# Altium schematic sheet pw_contr_v1_s1.SchDoc(pw_contr_v1_s1)
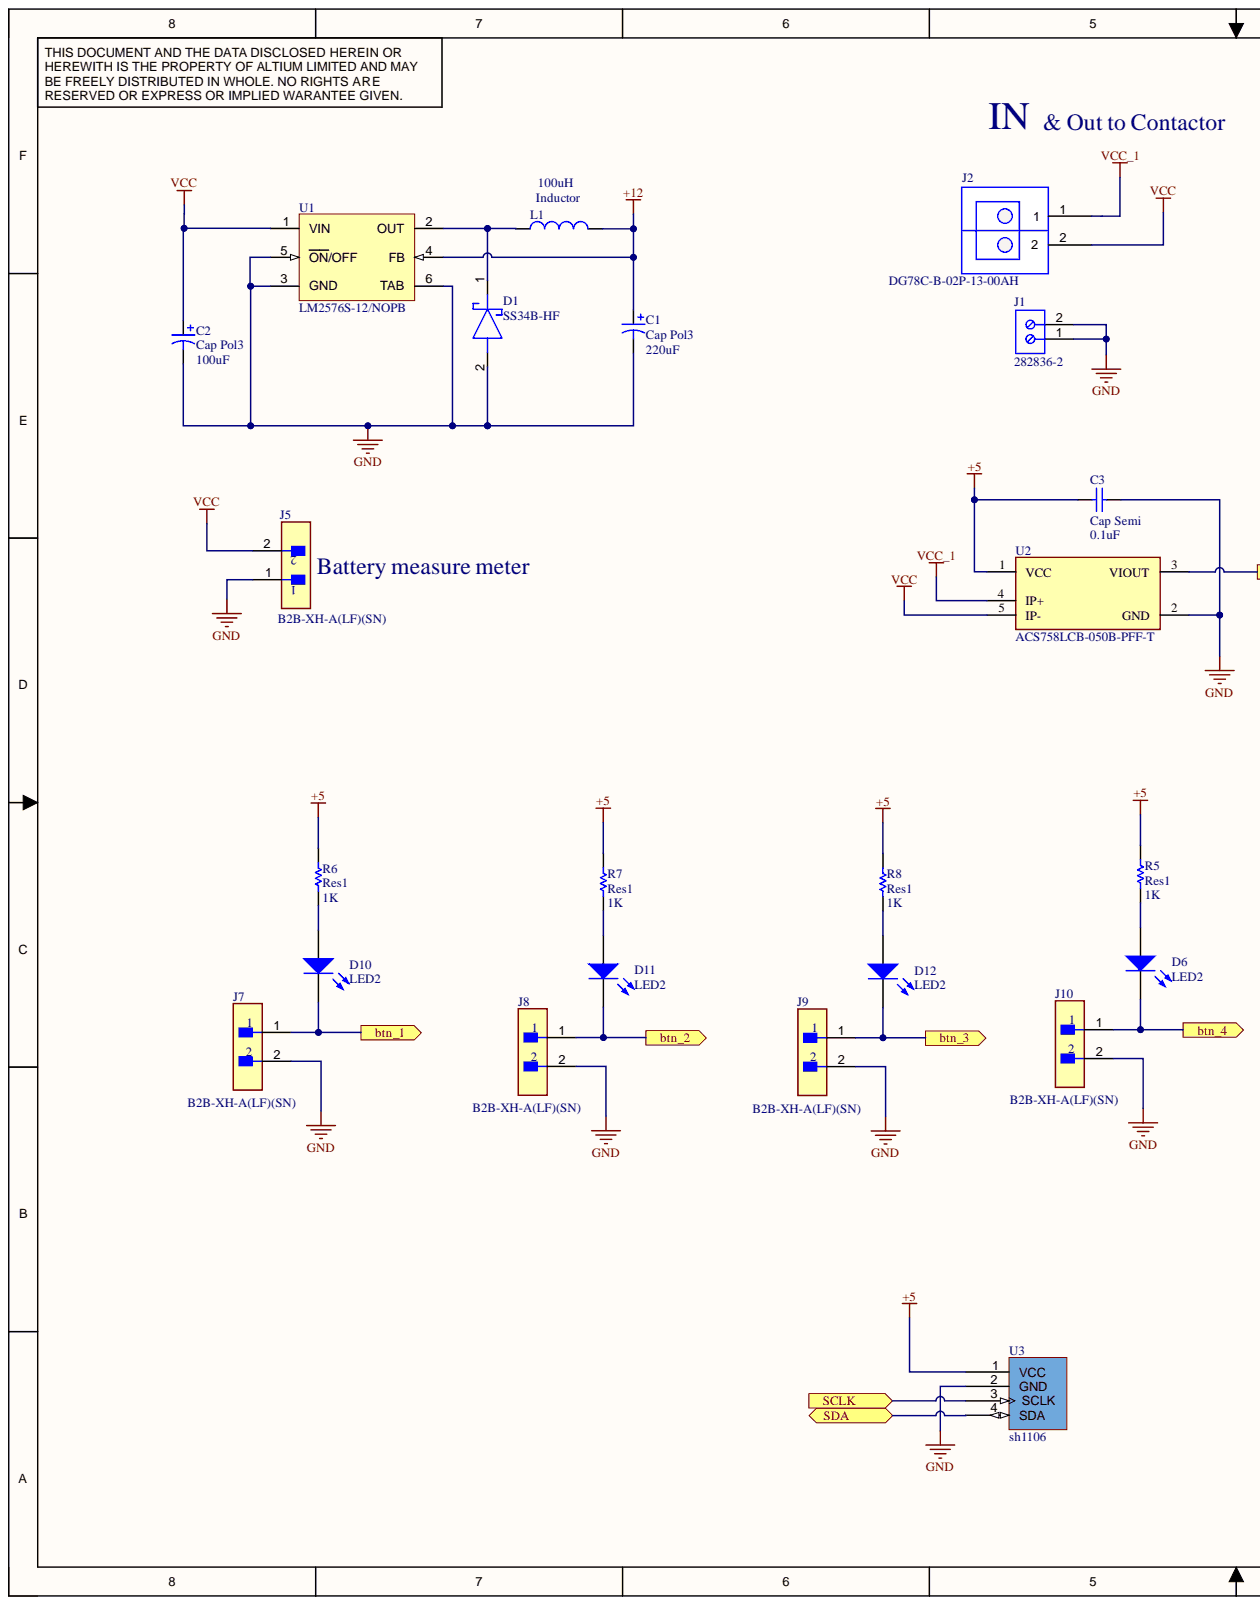
[diagram p0: pw_contr_v1_s1.SchDoc, left]
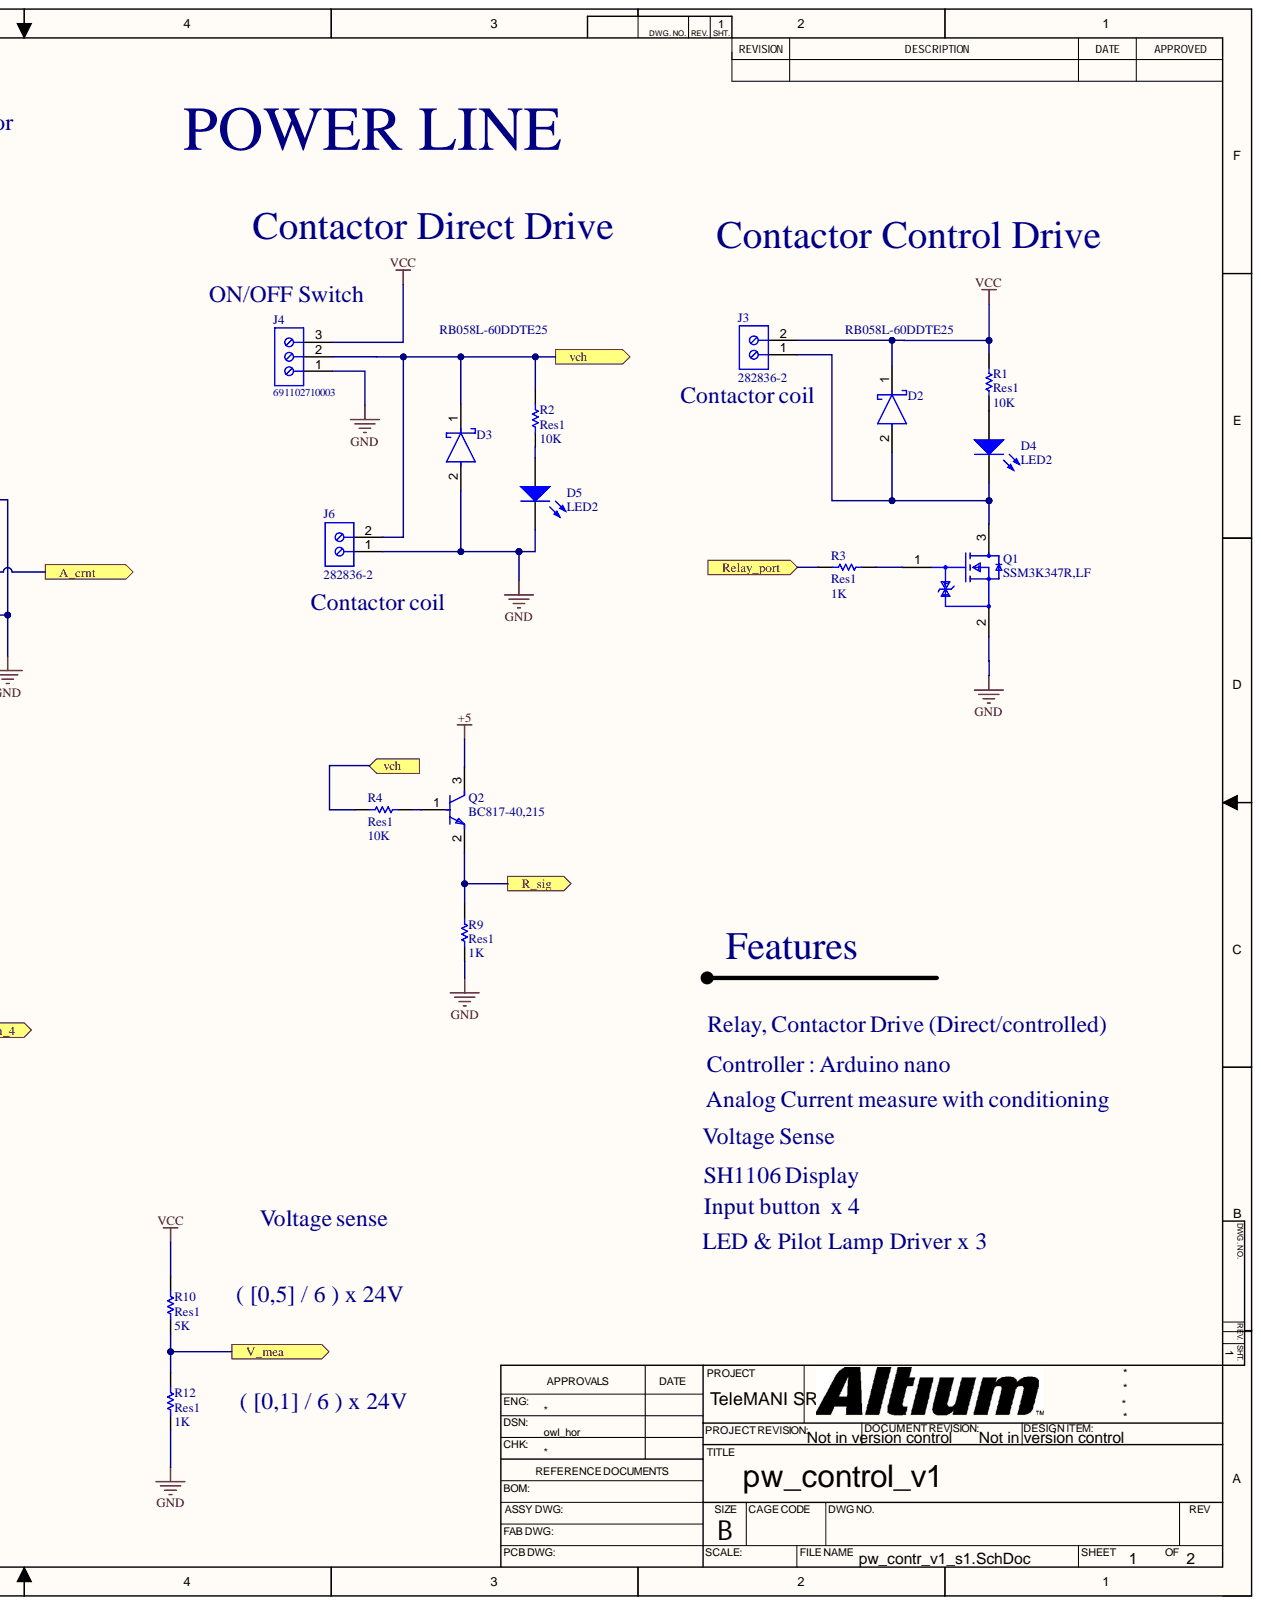
[diagram p1: pw_contr_v1_s1.SchDoc, right]

## Parts
C1  Cap Pol3  value 220uF  [CAPA_SMD_8x10]
C2  Cap Pol3  value 100uF  [CAPA_SMD_10x10]
C3  Cap Semi  value 0.1uF  [C1206]
D1  SS34B-HF  [DIOM5336X320N]
D2  RB058L-60DDTE25  [FP-PMDS-MFG]
D3  RB058L-60DDTE25  [FP-PMDS-MFG]
D4  LED2  [3.2X1.6X1.1]
D5  LED2  [3.2X1.6X1.1]
D6  LED2  [3.2X1.6X1.1]
D10  LED2  [3.2X1.6X1.1]
D11  LED2  [3.2X1.6X1.1]
D12  LED2  [3.2X1.6X1.1]
J1  282836-2  [TECO-282836-2_V]
J2  DG78C-B-02P-13-00AH  [DG78C-B-02P-13]
J3  282836-2  [TECO-282836-2_V]
J4  691102710003  [691102710003]
J5  B2B-XH-A(LF)(SN)  [FP-B2B-XH-A_LF_SN-MFG]
J6  282836-2  [TECO-282836-2_V]
J7  B2B-XH-A(LF)(SN)  [FP-B2B-XH-A_LF_SN-MFG]
J8  B2B-XH-A(LF)(SN)  [FP-B2B-XH-A_LF_SN-MFG]
J9  B2B-XH-A(LF)(SN)  [FP-B2B-XH-A_LF_SN-MFG]
J10  B2B-XH-A(LF)(SN)  [FP-B2B-XH-A_LF_SN-MFG]
L1  Inductor  value 100uH  [INDP9898X40N]
Q1  SSM3K347R,LF  [FP-SOT-23F-MFG]
Q2  BC817-40,215  [FP-SOT23-MFG]
R1  Res1  value 10K  [AXIAL-0.3]
R2  Res1  value 10K  [AXIAL-0.3]
R3  Res1  value 1K  [AXIAL-0.3]
R4  Res1  value 10K  [AXIAL-0.3]
R5  Res1  value 1K  [AXIAL-0.3]
R6  Res1  value 1K  [AXIAL-0.3]
R7  Res1  value 1K  [AXIAL-0.3]
R8  Res1  value 1K  [AXIAL-0.3]
R9  Res1  value 1K  [AXIAL-0.3]
R10  Res1  value 5K  [AXIAL-0.3]
R12  Res1  value 1K  [AXIAL-0.3]
U1  LM2576S-12/NOPB  [KTT0005B_M]
U2  ACS758LCB-050B-PFF-T  [FP-CB-5-PFF-MFG]
U3  sh1106  [SH1106]

## Ports
A_crnt, btn_1, btn_2, btn_3, btn_4, R_sig, Relay_port, SCLK, SDA, V_mea, vch

## Nets
+5: C3.1 Q2.3 R5.2 R6.2 R7.2 R8.2 U2.1(VCC) U3.1(VCC)
+12: C1.1 L1.2 U1.4(FB)
GND: C1.2 C2.2 C3.2 D1.2 D3.2 D5.2 J1.1 J1.2 J4.1 J5.1 J6.1 J7.2 J8.2 J9.2 J10.2 Q1.2 R9.1 R12.1 U1.3(GND) U1.5(ON/OFF) U1.6(TAB) U2.2(GND) U3.2(GND)
VCC: C2.1 D2.1 J2.2 J3.2 J4.3 J5.2 R1.2 R10.2 U1.1(VIN) U2.5(IP-)
VCC_1: J2.1 U2.4(IP+)
A_crnt: U2.3(VIOUT) ; port A_crnt
btn_1: D10.2 J7.1 ; port btn_1
btn_2: D11.2 J8.1 ; port btn_2
btn_3: D12.2 J9.1 ; port btn_3
btn_4: D6.2 J10.1 ; port btn_4
R_sig: Q2.2 R9.2 ; port R_sig
Relay_port: R3.1 ; port Relay_port
SCLK: U3.3(SCLK) ; port SCLK
SDA: U3.4(SDA) ; port SDA
V_mea: R10.1 R12.2 ; port V_mea
vch: D3.1 J4.2 J6.2 R2.2 R4.2 ; port vch
NetD1_1: D1.1 L1.1 U1.2(OUT)
NetD2_2: D2.2 D4.2 J3.1 Q1.3
NetD4_1: D4.1 R1.1
NetD5_1: D5.1 R2.1
NetD6_1: D6.1 R5.1
NetD10_1: D10.1 R6.1
NetD11_1: D11.1 R7.1
NetD12_1: D12.1 R8.1
NetQ1_1: Q1.1 R3.2
NetQ2_1: Q2.1 R4.1

## Library data of the parts
C1 C2 = Cap Pol3: Polarized Capacitor (Surface Mount); Component Kind: Standard; LatestRevisionDate: 29-May-2009; LatestRevisionNote: IPC-7351 Footprint Added.; Library Name: Miscellaneous Devices.IntLib; PackageDescription: Chip Capacitor; PackageReference: C0805; Published: 8-Jun-2000; Signal Integrity: Cap Pol; Simulation: CAP; Publisher: Altium Limited
C3 = Cap Semi: Capacitor (Semiconductor SIM Model); Component Kind: Standard; LatestRevisionDate: 29-May-2009; LatestRevisionNote: IPC-7351 Footprint Added.; Library Name: Miscellaneous Devices.IntLib; PackageDescription: Chip Capacitor; PackageReference: C1206; Published: 8-Jun-2000; Signal Integrity: Cap Semi; Simulation: CAP; Publisher: Altium Limited
D1 = SS34B-HF: Schottky Diode; Manufacturer_Name: Comchip Technology; Component Kind: Standard; Datasheet Link: https://www.mouser.jp/datasheet/2/80/SP-JB017_SS34B-HF_Thru._SS320B-HF_RevB-1847021.pdf; Height: 3.2mm; Manufacturer_Part_Number: SS34B-HF; Mouser Part Number: 750-SS34B-HF; Mouser Price/Stock: https://www.mouser.co.uk/ProductDetail/Comchip-Technology/SS34B-HF?qs=vmHwEFxEFR9G1M%252BcUPG%2FxQ%3D%3D
D2 D3 = CMP-08971-000003-1: DIODE SCHOTTKY 60V 3A PMDS; Manufacturer: Rohm; Automotive Grade: Grade 0; Max Junction Temp: +150°C; Max Surge Forward Current: 120A; Peak Reverse Current: 0.3uA; Automotive: Yes; Category: Diode; Component Kind: Standard; ComponentLink2Description: Datasheet; ComponentLink2URL: https://www.rohm.com/datasheet/RB058L-60DD/rb058l-60ddte25-e; Device Class L1: Discrete Semiconductors; Device Class L2: Diodes; Device Class L3: Schottky Diodes; Digikey Description: DIODE SCHOTTKY 60V 3A PMDS; Forward Current: 3A; Height: 2.2mm; Lead Free: Yes; Library Name: Altium Content Vault; Manufacturer Part Number: RB058L-60DDTE25; Max Repetitive Reverse Voltage: 60V; Mouser Description: Schottky Diodes & Rectifiers; Octopart: https://octopart.com/rb058l-60ddte25-rohm-66786667; Package: PMDS; Reverse Voltage: 60V; RoHS: TRUE; Temperature: to +150°C; Temperature Range High: +150°C
D4-D6 D10-D12 = LED2: Typical RED, GREEN, YELLOW, AMBER GaAs LED; Component Kind: Standard; LatestRevisionDate: 17-Jul-2002; LatestRevisionNote: Re-released for DXP Platform.; Library Name: Miscellaneous Devices.IntLib; PackageDescription: SM LED; 2 Flat Leads; PackageReference: 3.2X1.6X1.1; PackageVersion: Feb-2002; Published: 8-Jun-2000; Simulation: LED2; Publisher: Altium Limited
J1 J3 J6 = CMP-1684-00017-1: Female Header, Pitch 5 mm, 1 x 2 Position, Height 10 mm, Tail Length 3.5 mm, Rohs, Bulk; Manufacturer: TE Connectivity; 3D Model: 282836-2.pdf; Clamp Material - Plating: Brass-NickelPlated; Current: 13.5A; Dynamic Catalog: BuchananSeries; Featured Product: EurostyleTerminalBlockConnectors; Mating Orientation: HorizontalwithBoard; Number of Levels: 1; Other Names: 5ULV-02B  A98076; Pitch: 0.197"(5.00mm); Positions Per Level: 2; Product Training Modules: Wire-to-BoardEurostyleTerminalBlocks; Screw Thread: M3; Torque - Screw: 0.68Nm(6Lb-In); Voltage: 300V; Wire Gauge: 16-30AWG; Wire Termination: Screw-RisingCageClamp; Category: Connectors,Interconnects; Color: Green; Component Kind: Standard; Contact Material - Plating: Brass-TinPlated; Datasheet URL: http://www.te.com.cn/commerce/DocumentDelivery/DDEController?Action=showdoc&DocId=Catalog+Section%7F1308389_EUROSTYLE_TERMINAL_BLOCKS%7F0607%7Fpdf%7FEnglish%7FENG_CS_1308389_EUROSTYLE_TERMINAL_BLOCKS_0607.pdf%7F282836-2; Family: TerminalBlocks-WiretoBoard; Features: Interlocking(Side); Housing Material: Polyamide(PA66),Nylon6/6; Library Name: Altium Content Vault; Manufacturer URL: http://www.te.com; Mounting Type: ThroughHole; Package Description: Female Header, Pitch 5 mm, 1 x 2 Position, Height 10 mm, Tail Length 3.5 mm; Package Reference: THD; Packaging: Bulk; RoHS Information: 282836-2StatementofCompliance; Screw Material - Plating: Brass-NickelPlated; Series: Buchanan; Standard Package: 300
J2 = DG78C-B-02P-13-00AH: Barrier Terminal 2P, 13mm pitch; Component Kind: Standard; Library Name: Schlib1.SchLib
J4 = CMP-1502-03259-1: Series 102 - 5.00 mm Horizontal Entry Modular with Pressure Clamp WR-TBL, 3 pin; Manufacturer: Wurth Elektronik; Pin Number: 3; Pitch: 5.00mm; Component Kind: Standard; Datasheet URL: http://katalog.we-online.de/em/datasheet/6911027100xx.pdf; Library Name: Altium Content Vault; Manufacturer URL: http://www.we-online.de; Mounting Technology: THT; Operating Temperature: -40to105degC; Package Reference: 691102710003; Packaging: Box; Part Number: 691102710003; Publisher: WurthElektronik
J5 J7-J10 = CMP-2000-05888-3: CONN HEADER VERT 2POS 2.5MM; Connector Type: Male Pin; Contact Resistance: 20mR; Current Rating: 3A; Insulation Resistance: 1GR; Max Voltage Rating (AC): 250V; Number of Contacts: 2; Wire/Cable Gauge: 22AWG; Color: Natural; Component Kind: Standard; Contact Material: Brass; Contact Plating: Tin; Contact Type: Male Pin; Depth: 5.75mm; Fastening Type: Detent; Housing Color: White; Housing Material: Polyamide; Lead Free: Lead Free; Lead Pitch: 2.5mm; Length: 7.4mm; Library Name: Altium Content Vault; Max Current Rating: 3A; Max Operating Temperature: 85°C; Max Voltage Rating (DC): 250V; Min Operating Temperature: -25°C; Mount: Through Hole; Number of Positions: 2; Number of Rows: 1; Orientation: Straight; Packaging: Bulk; Pitch: 2.5mm; REACH SVHC: No SVHC; Series: XH; Termination: Solder; Voltage Rating: 250V; Voltage Rating (AC): 250V
L1 = Inductor: Code_IEC: 1005; Code_JEITA: 0402; Component Kind: Standard; Description: Inductor; LatestRevisionDate: 29-May-2009; LatestRevisionNote: IPC-7351 Footprint Added.; Library Name: Miscellaneous Devices.IntLib; PackageDescription: Chip Inductor; PackageReference: 0402-A; Published: 8-Jun-2000; Signal Integrity: Inductor; Simulation: INDUCTOR; Publisher: Altium Limited
Q1 = CMP-35916-000012-1: MOSFET N-CHANNEL 20V 4A SOT23F; Component Kind: Standard; Library Name: Altium Content Vault
Q2 = CMP-14406-000007-1: TRANS NPN 45V 0.5A SOT23; Manufacturer: Nexperia; Automotive Grade: Grade 0; Collector Base Voltage: 50V; Collector Emitter Saturation Voltage: 700mV; Emitter Base Voltage: 5V; Hfe Max: 600; Hfe Min: 250; Hfe Testic: 100mA; Hfe Testvce: 1V; Max Collector Current: 500mA; Max Junction Temp: +150°C; Number Of Elements: 1; Power Dissipation: 250mW; Rthja Max: 500K/W; Unity Gain Freq: 100MHz; Ambient Temperature Range High: +150°C; Ambient Temperature Range Low: -65°C; Automotive: Yes; Category: Trans; Collector Emitter Breakdown Voltage: 45V; Collector Emitter Voltage: 45V; Component Kind: Standard; ComponentLink2Description: Datasheet; ComponentLink2URL: https://assets.nexperia.com/documents/data-sheet/BC817_SER.pdf; Device Class L1: Discrete Semiconductors; Device Class L2: Transistors; Device Class L3: BJTs; Digikey Description: TRANS NPN 45V 0.5A SOT23; Footprint Url: https://assets.nexperia.com/documents/outline-drawing/SOT23.pdf; Height: 1.1mm; Ipc Land Pattern Name: SOT95P230X100-3; Lead Free: Yes; Library Name: Altium Content Vault; Manufacturer Part Number: BC817-40,215; Mouser Description: BC817 Series 45 V 500 mA 250 mW SMT NPN General-Purpose Transistor - SOT-23-; Number Of Npn: 1; Octopart: https://octopart.com/bc817-40%2C215-nexperia-78739081; Package: SOT23; RoHS: TRUE; Temperature: to +150°C; Temperature Range High: +150°C
R1-R10 R12 = Res1: Resistor; Component Kind: Standard; LatestRevisionDate: 17-Jul-2002; LatestRevisionNote: Re-released for DXP Platform.; Library Name: Miscellaneous Devices.IntLib; PackageDescription: Axial Device, Thru-Hole; 2 Leads; 0.3 in Pin Spacing; PackageReference: AXIAL-0.3; Published: 8-Jun-2000; Signal Integrity: Res; Simulation: RESISTOR; Publisher: Altium Limited
U1 = CMP-0069-00125-3: SIMPLE SWITCHER® 3A Step-Down Voltage Regulator, 5-pin TO-263, Pb-Free; Manufacturer: Texas Instruments; PackageTopMarking: NSUZXYTTE#*LM2576S*-12 P+; PowerWise: N; Code_JEDEC: MO-169-AB; Component Kind: Standard; ComponentLink1Description: Datasheet URL; ComponentLink1URL: http://www.ti.com/general/docs/lit/getliterature.tsp?genericPartNumber=LM2576&fileType=pdf; CompType: Switcher; Library Name: Altium Content Vault; PackageDescription: TO-263, Molded, .400 x .390 x .180in, 5 Lead, .067in Pitch, Surface Mount; PackageReference: KTT0005B; PinCount: 5; RoHS: Y
U2 = CMP-82923-000254-1: SENSOR CURRENT HALL 50A AC/DC; Accuracy: 2%; Bandwidth: 120kHz; Linearity: 1%; Max Supply Current: 13.5mA; Max Supply Voltage: 5.5V; Min Supply Voltage: 3V; Number of Channels: 1; Operating Supply Voltage: 5V; Output Current: 1mA; Polarity: Bidirectional; Quiescent Current: 10mA; Response Time: 4us; Sensitivity: 40mV/A; Component Kind: Standard; Current Sensing: 50A; Frequency: 120kHz; Height: 7mm; Lead Free: Lead Free; Length: 10mm; Library Name: Altium Content Vault; Max Operating Temperature: 150°C; Min Operating Temperature: -40°C; Mount: Through Hole; Number of Pins: 5; Package Quantity: 34; Packaging: Rail/Tube; Radiation Hardening: No; REACH SVHC: No SVHC; RoHS Compliant: Yes; Series: -; Width: 13mm
U3 = SH1106: sh1106 OLED display module; Component Kind: Standard; Library Name: Schlib1.SchLib
